# Altium schematic sheet Power.SchDoc
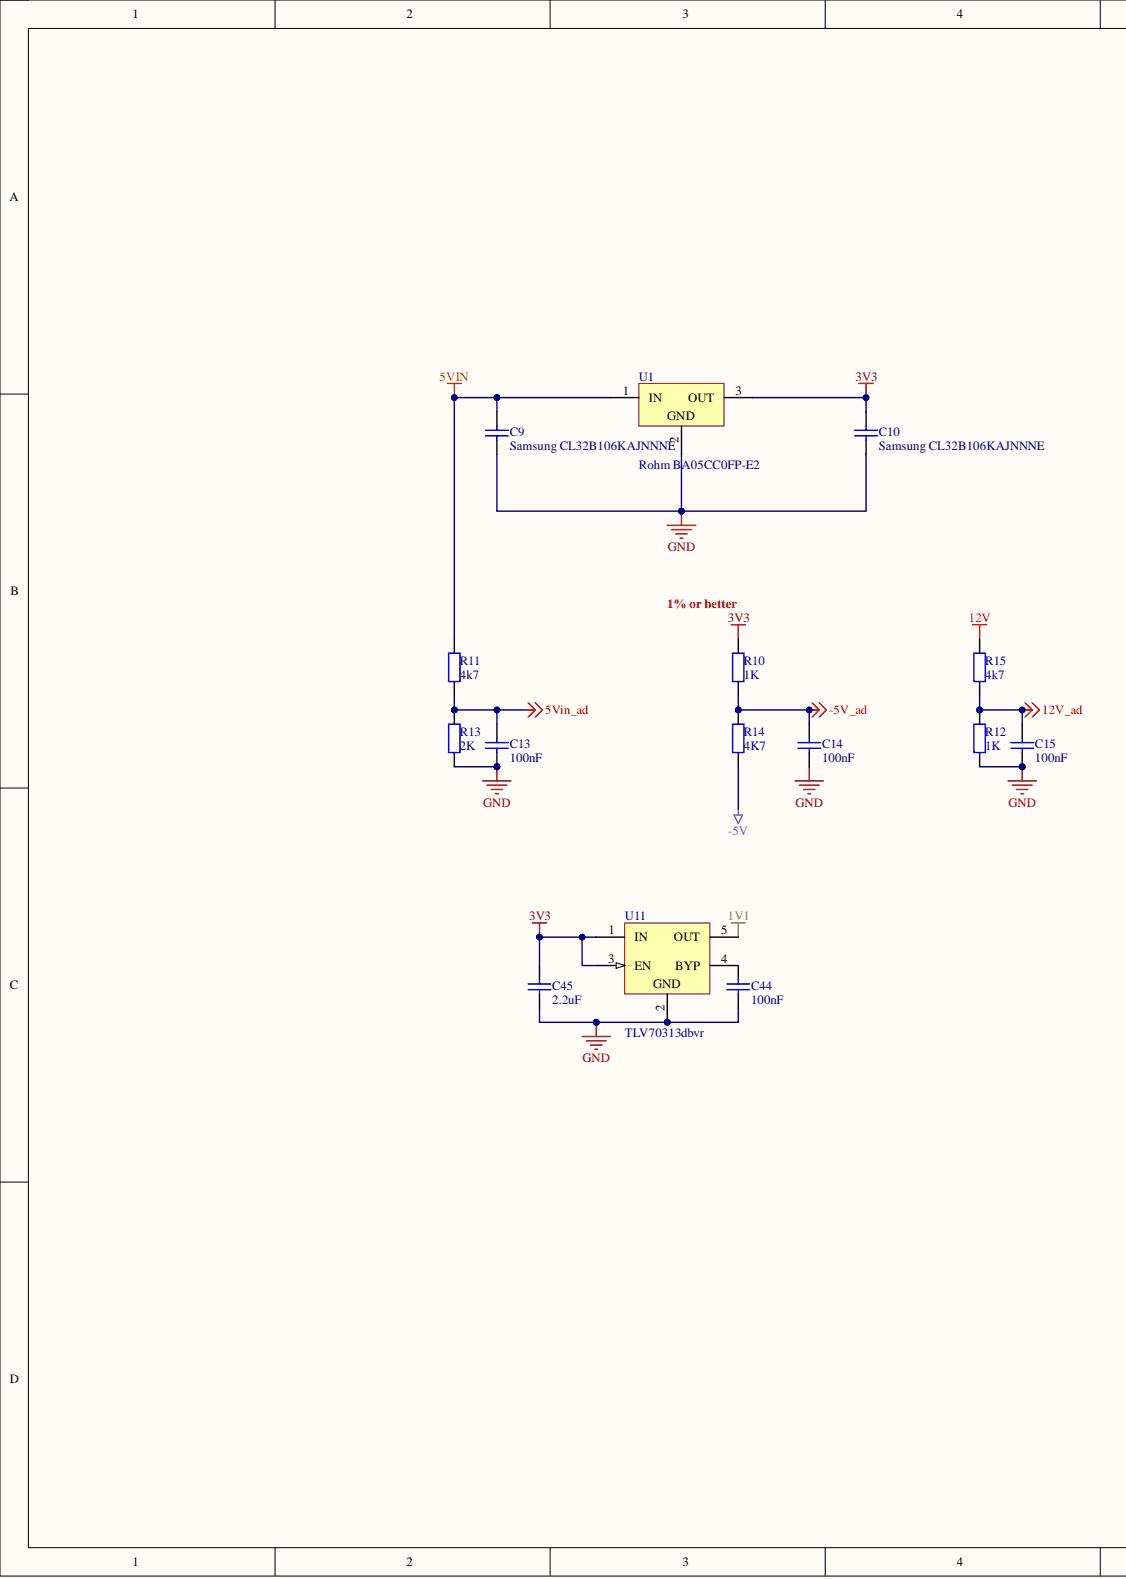
[diagram p0: Power.SchDoc, left]
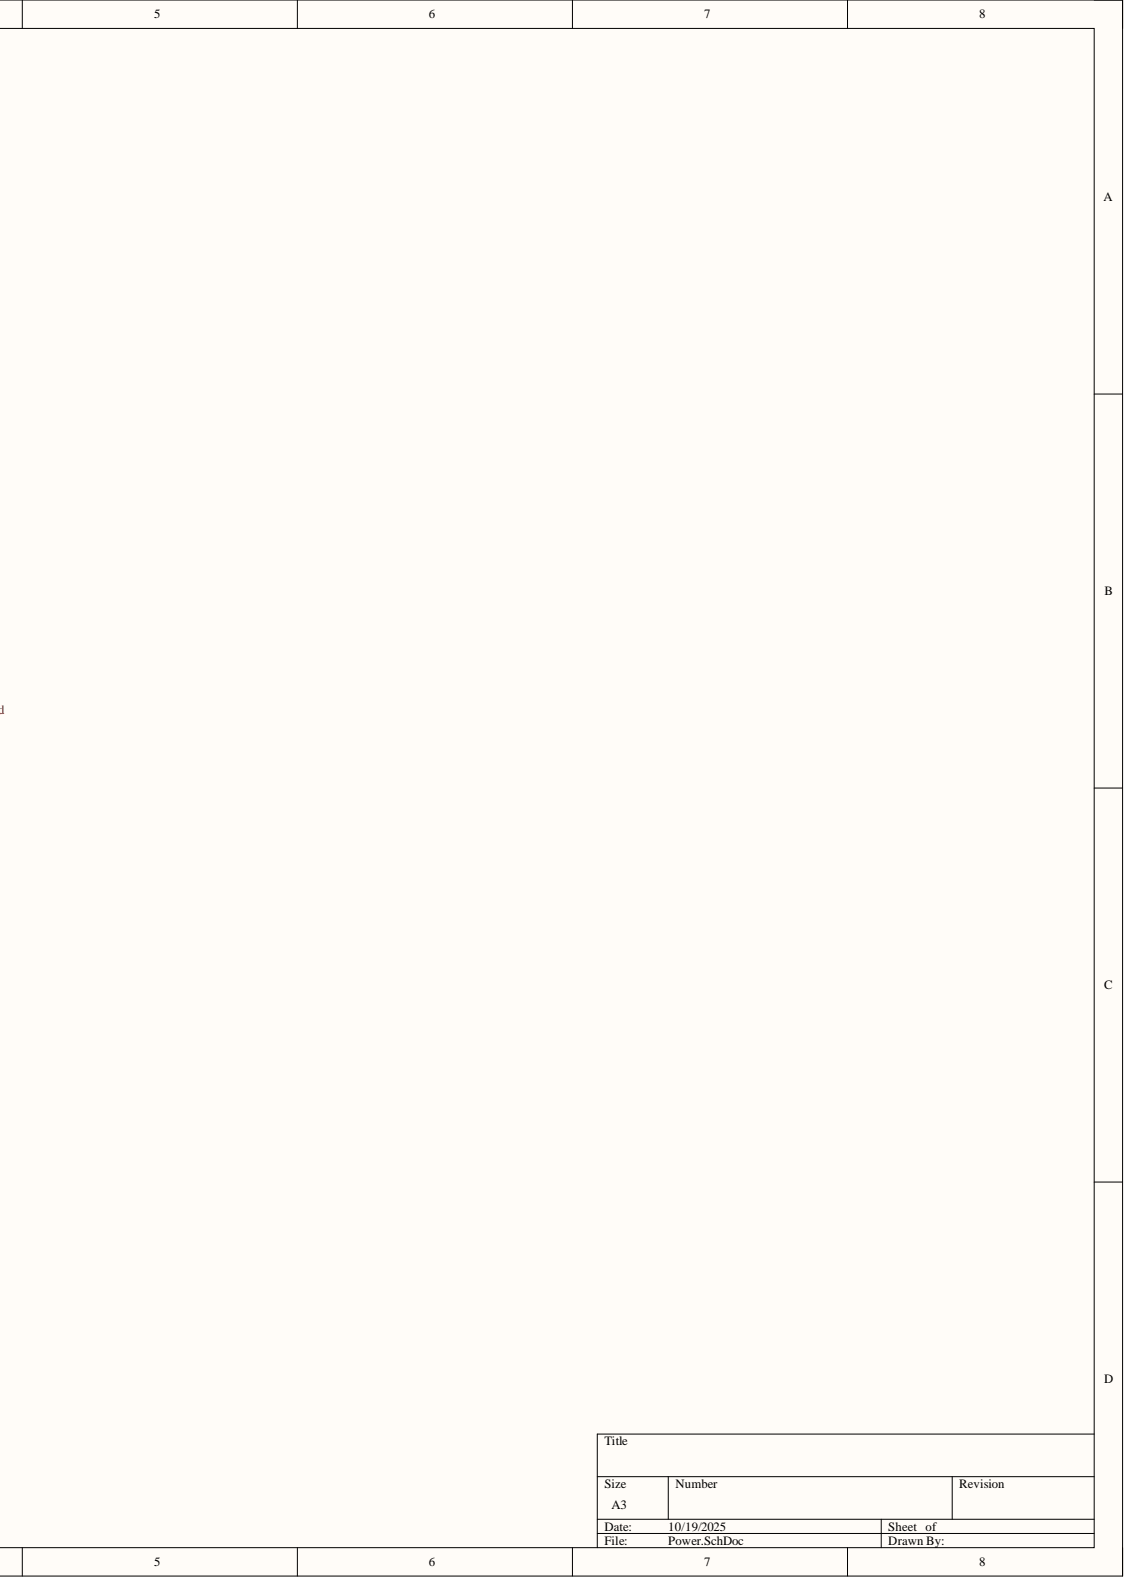
[diagram p1: Power.SchDoc, right]

## Parts
C9  Samsung CL32B106KAJNNNE  [PCB-2anwujj7xwxoywzjnffd-1]
C10  Samsung CL32B106KAJNNNE  [PCB-2anwujj7xwxoywzjnffd-1]
C13  100nF  [PCB-god88euyegdvhk3bnqo6-1]
C14  100nF  [PCB-god88euyegdvhk3bnqo6-1]
C15  100nF  [PCB-god88euyegdvhk3bnqo6-1]
C44  100nF  [PCB-god88euyegdvhk3bnqo6-1]
C45  2.2uF  [PCB-god88euyegdvhk3bnqo6-1]
R10  1K  [PCB-7wrvqjq7seaxrusqyahf-1]
R11  4k7  [PCB-7wrvqjq7seaxrusqyahf-1]
R12  1K  [PCB-7wrvqjq7seaxrusqyahf-1]
R13  2K  [PCB-7wrvqjq7seaxrusqyahf-1]
R14  4K7  [PCB-7wrvqjq7seaxrusqyahf-1]
R15  4k7  [PCB-7wrvqjq7seaxrusqyahf-1]
U1  Rohm BA05CC0FP-E2  Manufacturer Part Number: BA05CC0FP-E2  [PCB-1pdn1hr4w8ei2zb92zqz-1]
U11  TLV70313dbvr  [STMicroelectronics LDK130M29R-Footprint-1]

## Nets
1V1: U11.5(OUT)
3V3: C10.2 C45.1 R10.1 U1.3 U11.1(IN) U11.3(EN)
5VIN: C9.2 R11.1 U1.1
5Vin_ad: C13.2 R11.2 R13.1
12V: R15.1
12V_ad: C15.2 R12.1 R15.2
-5V: R14.2
-5V_ad: C14.2 R10.2 R14.1
GND: C9.1 C10.1 C13.1 C14.1 C15.1 C44.1 C45.2 R12.2 R13.2 U1.2 U11.2
NetC44_2: C44.2 U11.4(BYP)

## Library data of the parts
C9 C10 = CMP-ccd6055105851311-1: CL32 Series 10 uF 25 V ±10 % Tolerance X7R SMT Multilayer Ceramic Capacitor; Capacitance: 10.0 µF; Capacitance Tolerance: ±10%; Lead-Free Status: Lead Free; Voltage Rating (DC): 25.0 V; Case/Package: 1210; Component Kind: Standard; Dielectric Characteristic: X7R; Library Name: My Personal Space; Manufacturer Part Number: CL32B106KAJNNNE; Mounting Style: Surface Mount; Octopart URL: http://octopart.com/cl32b106kajnnne-samsung-9301045; OctopartID: ccd6055105851311; RoHS: Compliant
C13-C15 C44 C45 = CMP-ee2a246dec7f31ed-7: Capacitor; Ceramic; Cap 0.1 uF; Tol 10%; Vol-Rtg 25 VDC; SMT; 0805; X7R; Tape & Reel; Component Kind: Standard; Library Name: My Personal Space
R10-R15 = CMP-3bd8f394a633e41f-2: Res Thin Film 2.0 x 1.2 mm 2K Ohm 0.1% 0.1W(1/10W) 10ppm/ C Molded SMD Paper T/R; Manufacturer Part Number: RN73C2A2K0BTD; Case/Package (SI): 2012; ELV Compliant: Compliant; Power Rating: 100 mW; Resistance: 2.00 kΩ; Voltage Rating (DC): 100 V; Case/Package: 0805; Component Kind: Standard; Library Name: My Personal Space; Lifecycle Status: Active; Mounting Style: Surface Mount; Number of Pins: 2; Octopart URL: http://octopart.com/rn73c2a2k0btdf-te+connectivity-21411981; OctopartID: 3bd8f394a633e41f; Packaging: Tape & Reel (TR); REACH SVHC Compliance: No SVHC; Resistance Tolerance: ±0.1%; RoHS: Compliant
U1 = CMP-9dcb54c9b6f9420c-1: In a Pack of 10, ROHM BA05CC0FP-E2, LDO Regulator, 1A, 5 V, ±1% 3-Pin, DPAK; Input Voltage (DC): 35.0 V (max); Lead-Free Status: Lead Free; Output Current: 1.00 A; Case/Package: TO-252; Component Kind: Standard; Library Name: My Personal Space; Mounting Style: Surface Mount; Number of Pins: 3; Octopart URL: https://octopart.com/ba05cc0fp-e2-rohm-527956; OctopartID: 9dcb54c9b6f9420c; Operating Temperature: 125 °C (max); Packaging: Cut Tape (CT); RoHS: Compliant
U11 = CMP-35014348-1: LDO Regulator Pos 2.9V 0.3A 5-Pin SOT-23 T/R; Manufacturer Part Number: LDK130M29R; Accuracy: 2 %; Dropout Voltage: 400 mV; Max Input Voltage: 5.5 V; Max Output Current: 300 mA; Min Input Voltage: 1.9 V; Number of Channels: 1; Operating Supply Current: 70 µA; Output Type: Fixed; Polarity: Positive; Power Supply Rejection Ratio (PSRR): 55 dB; Quiescent Current: 60 µA; Case/Package: SOT-23-5; Component Kind: Standard; Lead Free: Lead Free; Library Name: My Personal Space; Lifecycle Status: Production (Last Updated: 4 years ago); Max Operating Temperature: 125 °C; Min Operating Temperature: -40 °C; Mount: Surface Mount; Nominal Output Voltage: 2.9 V; Number of Outputs: 1; Number of Pins: 5; Number of Regulators: 1; Octopart Url: https://octopart.com/ldk130m29r-stmicroelectronics-35014348; OctopartID: 35014348; Output Current: 300 mA; Output Voltage: 2.9 V; Packaging: Cut Tape; Radiation Hardening: No; REACH SVHC: No SVHC; RoHS: Compliant; Schedule B: 8542390000; Weight: 29.993795 mg
